# Altium schematic sheet mainSch.SchDoc
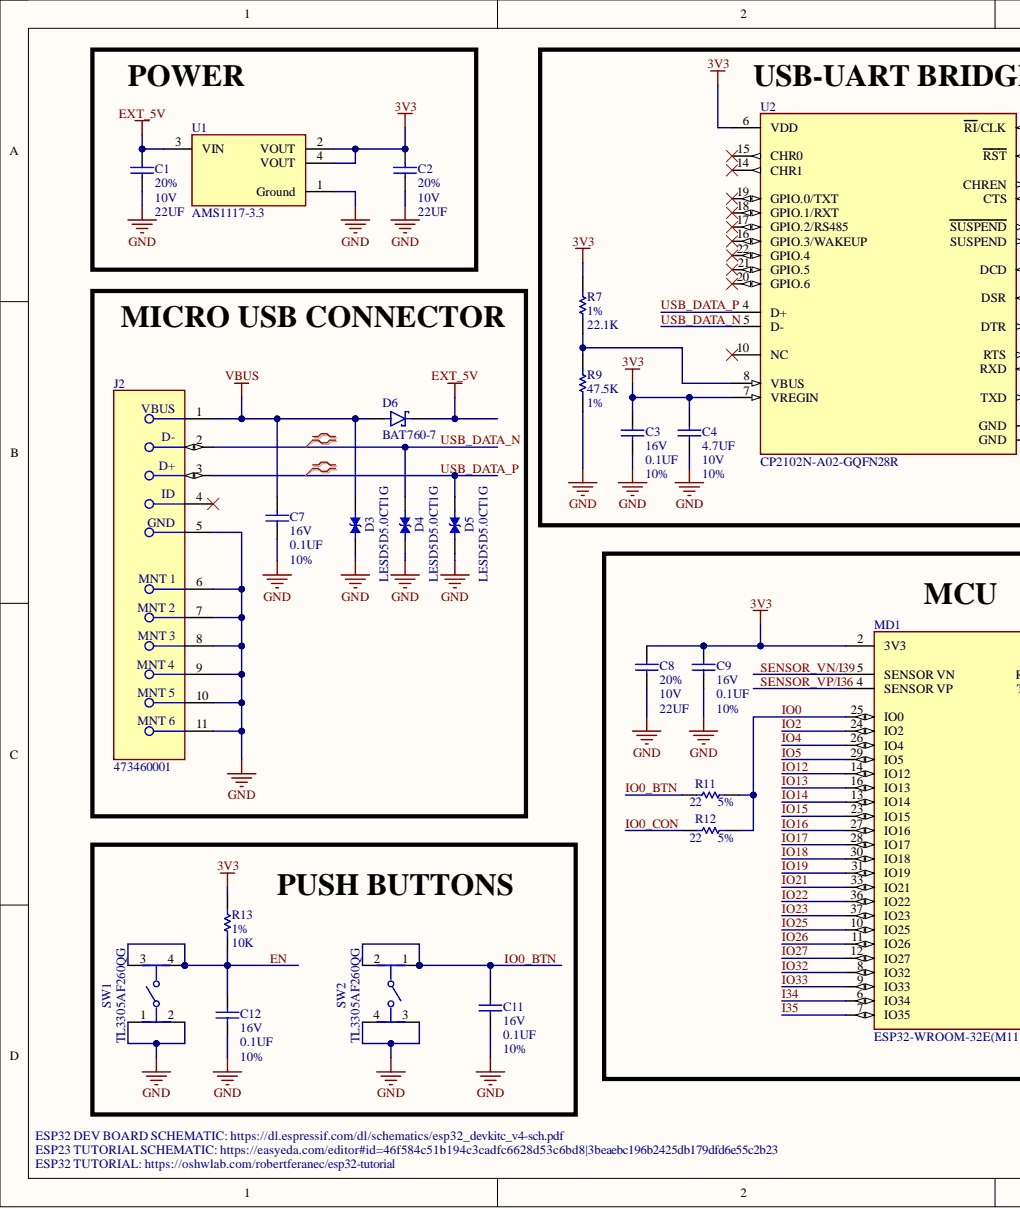
[diagram p0: mainSch.SchDoc, left]
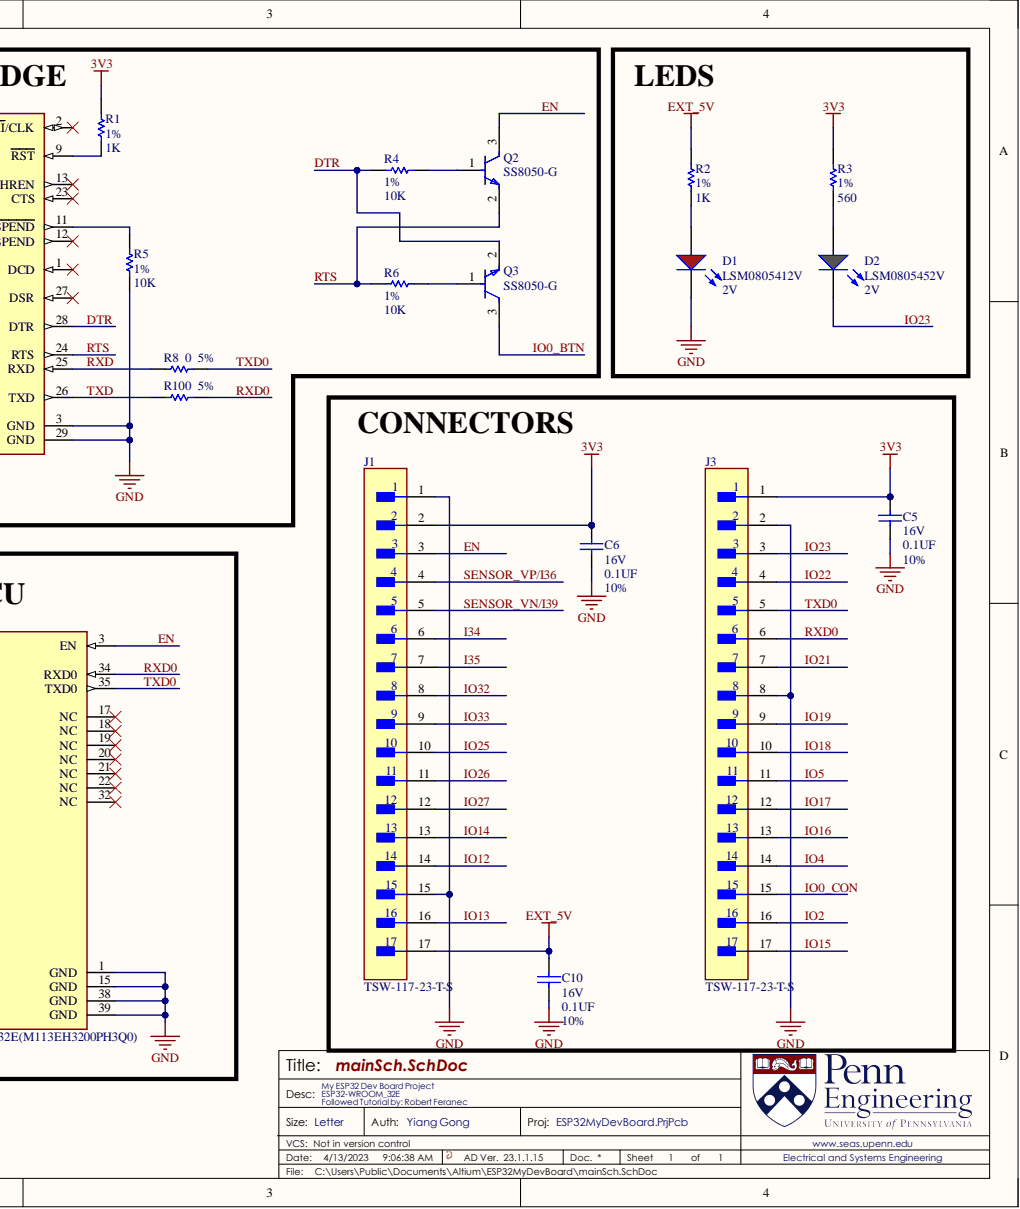
[diagram p1: mainSch.SchDoc, right]

## Parts
C1  value 22UF  Tolerance: 20%  Voltage: 10V  [0805_CAP_60H]  (hidden comment: CAP 0805 22UF 10V 20% X5R)
C2  value 22UF  Tolerance: 20%  Voltage: 10V  [0805_CAP_60H]  (hidden comment: CAP 0805 22UF 10V 20% X5R)
C3  value 0.1UF  Tolerance: 10%  Voltage: 16V  [0402_CAP]  (hidden comment: CAP 0402 0.1UF 16V 10% X7R)
C4  value 4.7UF  Tolerance: 10%  Voltage: 10V  [0603_CAP]  (hidden comment: CAP 0603 4.7UF 10V 10% X5R)
C5  value 0.1UF  Tolerance: 10%  Voltage: 16V  [0402_CAP]  (hidden comment: CAP 0402 0.1UF 16V 10% X7R)
C6  value 0.1UF  Tolerance: 10%  Voltage: 16V  [0402_CAP]  (hidden comment: CAP 0402 0.1UF 16V 10% X7R)
C7  value 0.1UF  Tolerance: 10%  Voltage: 16V  [0402_CAP]  (hidden comment: CAP 0402 0.1UF 16V 10% X7R)
C8  value 22UF  Tolerance: 20%  Voltage: 10V  [0805_CAP_60H]  (hidden comment: CAP 0805 22UF 10V 20% X5R)
C9  value 0.1UF  Tolerance: 10%  Voltage: 16V  [0402_CAP]  (hidden comment: CAP 0402 0.1UF 16V 10% X7R)
C10  value 0.1UF  Tolerance: 10%  Voltage: 16V  [0402_CAP]  (hidden comment: CAP 0402 0.1UF 16V 10% X7R)
C11  value 0.1UF  Tolerance: 10%  Voltage: 16V  [0402_CAP]  (hidden comment: CAP 0402 0.1UF 16V 10% X7R)
C12  value 0.1UF  Tolerance: 10%  Voltage: 16V  [0402_CAP]  (hidden comment: CAP 0402 0.1UF 16V 10% X7R)
D1  LSM0805412V  Forward Voltage: 2V  [LED_0805_RED]
D2  LSM0805452V  Forward Voltage: 2V  [LED_0805]
D3  LESD5D5.0CT1G  [TVS_LESD5D5.0CT1G]
D4  LESD5D5.0CT1G  [TVS_LESD5D5.0CT1G]
D5  LESD5D5.0CT1G  [TVS_LESD5D5.0CT1G]
D6  BAT760-7  [SOD2513X120N]
J1  TSW-117-23-T-S  [FP-TSW-117-23-T-S-MFG]
J2  473460001  [FP-473460001-MFG]
J3  TSW-117-23-T-S  [FP-TSW-117-23-T-S-MFG]
MD1  ESP32-WROOM-32E(M113EH3200PH3Q0)  [FP-ESP32-WROOM-32E_M113EH-MFG]
Q2  SS8050-G  [FP-SS8050-G-MFG]
Q3  SS8050-G  [FP-SS8050-G-MFG]
R1  value 1K  Tolerance: 1%  [0402_RES]  (hidden comment: RES 0402 1K 1%)
R2  value 1K  Tolerance: 1%  [0402_RES]  (hidden comment: RES 0402 1K 1%)
R3  value 560  Tolerance: 1%  [0402_RES]  (hidden comment: RES 0402 560 1%)
R4  value 10K  Tolerance: 1%  [0402_RES]  (hidden comment: RES 0402 10K 1%)
R5  value 10K  Tolerance: 1%  [0402_RES]  (hidden comment: RES 0402 10K 1%)
R6  value 10K  Tolerance: 1%  [0402_RES]  (hidden comment: RES 0402 10K 1%)
R7  value 22.1K  Tolerance: 1%  [0402_RES]  (hidden comment: RES 0402 22.1K 1%)
R8  value 0  Tolerance: 5%  [0402_RES]  (hidden comment: RES 0402 0 5%)
R9  value 47.5K  Tolerance: 1%  [0402_RES]  (hidden comment: RES 0402 47.5K 1%)
R10  value 0  Tolerance: 5%  [0402_RES]  (hidden comment: RES 0402 0 5%)
R11  value 22  Tolerance: 5%  [0402_RES]  (hidden comment: RES 0402 22 5%)
R12  value 22  Tolerance: 5%  [0402_RES]  (hidden comment: RES 0402 22 5%)
R13  value 10K  Tolerance: 1%  [0402_RES]  (hidden comment: RES 0402 10K 1%)
SW1  TL3305AF260QG  [FP-TL3305AF260QG-MFG]
SW2  TL3305AF260QG  [FP-TL3305AF260QG-MFG]
U1  AMS1117-3.3  [FP-AMS1117-IPC_C]
U2  CP2102N-A02-GQFN28R  [FP-CP2102N-A02-GQFN28R-MFG]

## Nets
3V3: C2.2 C3.2 C4.2 C5.2 C6.2 C8.2 C9.2 J1.2 J3.1 MD1.2(3V3) R1.2 R3.2 R7.2 R13.2 U1.2(VOUT) U1.4(VOUT) U2.6(VDD) U2.7(VREGIN)
EXT_5V: C1.2 C10.2 D6.C J1.17 R2.2 U1.3(VIN)
GND: C1.1 C2.1 C3.1 C4.1 C5.1 C6.1 C7.1 C8.1 C9.1 C10.1 C11.1 C12.1 D1.K D3.1 D4.1 D5.1 J1.1 J1.15 J2.5 J2.6 J2.7 J2.8 J2.9 J2.10 J2.11 J3.2 J3.8 MD1.1(GND) MD1.15(GND) MD1.38(GND) MD1.39(GND) R5.1 R9.1 SW1.1 SW1.2 SW2.3 SW2.4 U1.1(Ground) U2.3(GND) U2.29(GND)
VBUS: C7.2 D3.2 D6.A J2.1
DTR: Q3.2 R4.1 U2.28(DTR)
EN: C12.2 J1.3 MD1.3(EN) Q2.3 R13.1 SW1.3 SW1.4
I34: J1.6 MD1.6(IO34)
I35: J1.7 MD1.7(IO35)
IO0: MD1.25(IO0) R11.2 R12.2
IO0_BTN: C11.2 Q3.3 R11.1 SW2.1 SW2.2
IO0_CON: J3.15 R12.1
IO2: J3.16 MD1.24(IO2)
IO4: J3.14 MD1.26(IO4)
IO5: J3.11 MD1.29(IO5)
IO12: J1.14 MD1.14(IO12)
IO13: J1.16 MD1.16(IO13)
IO14: J1.13 MD1.13(IO14)
IO15: J3.17 MD1.23(IO15)
IO16: J3.13 MD1.27(IO16)
IO17: J3.12 MD1.28(IO17)
IO18: J3.10 MD1.30(IO18)
IO19: J3.9 MD1.31(IO19)
IO21: J3.7 MD1.33(IO21)
IO22: J3.4 MD1.36(IO22)
IO23: D2.K J3.3 MD1.37(IO23)
IO25: J1.10 MD1.10(IO25)
IO26: J1.11 MD1.11(IO26)
IO27: J1.12 MD1.12(IO27)
IO32: J1.8 MD1.8(IO32)
IO33: J1.9 MD1.9(IO33)
RTS: Q2.2 R6.1 U2.24(RTS)
RXD: R8.1 U2.25(RXD)
RXD0: J3.6 MD1.34(RXD0) R10.2
SENSOR_VN/I39: J1.5 MD1.5(SENSOR_VN)
SENSOR_VP/I36: J1.4 MD1.4(SENSOR_VP)
TXD: R10.1 U2.26(TXD)
TXD0: J3.5 MD1.35(TXD0) R8.2
USB_DATA_N: D4.2 J2.2 U2.5(D-)
USB_DATA_P: D5.2 J2.3 U2.4(D+)
NetD1_A: D1.A R2.1
NetD2_A: D2.A R3.1
NetQ2_1: Q2.1 R4.2
NetQ3_1: Q3.1 R6.2
NetR1_1: R1.1 U2.9(RST)
NetR5_2: R5.2 U2.11(SUSPEND)
NetR7_1: R7.1 R9.2 U2.8(VBUS)

Not connected: J2 4; MD1 17(NC) 18(NC) 19(NC) 20(NC) 21(NC) 22(NC) 32(NC); U2 1(DCD) 2(RI/CLK) 10(NC) 12(SUSPEND) 13(CHREN) 14(CHR1) 15(CHR0) 16(GPIO.3/WAKEUP) 17(GPIO.2/RS485) 18(GPIO.1/RXT) 19(GPIO.0/TXT) 20(GPIO.6) 21(GPIO.5) 22(GPIO.4) 23(CTS) 27(DSR)

## Library data of the parts
C1 C2 C8 = CMP-011-000507-1: Capacitor Surface Mount 0805 Footprint 22UF 10V 20% Tolerance Ceramic X5R; Manufacturer: Various; Description in Visual: Cap SMT 0805 22UF 10V 20%  Cer X5R; PCB Package: 0805; Component Kind: Standard; Library Name: University of Pennsylvania (ESE Labs); Manufacturer P/N: CAP 0805 22UF 10V 20% X5R; Mount: SMT; P/N: CAP 0805 22UF 10V 20% X5R; Type: Ceramic X5R
  C1: Value: 22UF
C3 C5-C7 C9-C12 = CMP-011-000043-1: Capacitor Surface Mount 0402 Footprint 0.1UF 16V 10% Tolerance Ceramic X7R; Manufacturer: Various; Description in Visual: Cap SMT 0402 0.1UF 16V 10%  Cer X7R; PCB Package: 0402; Component Kind: Standard; Library Name: University of Pennsylvania (ESE Labs); Manufacturer P/N: CAP 0402 0.1UF 16V 10% X7R; Mount: SMT; P/N: CAP 0402 0.1UF 16V 10% X7R; Type: Ceramic X7R
C4 = CMP-011-000329-1: Capacitor Surface Mount 0603 Footprint 4.7UF 10V 10% Tolerance Ceramic X5R; Manufacturer: Various; Description in Visual: Cap SMT 0603 4.7UF 10V 10%  Cer X5R; PCB Package: 0603; Component Kind: Standard; Library Name: University of Pennsylvania (ESE Labs); Manufacturer P/N: CAP 0603 4.7UF 10V 10% X5R; Mount: SMT; P/N: CAP 0603 4.7UF 10V 10% X5R; Type: Ceramic X5R
D1 = CMP-00026-00001-1: Single Color LED, RED, 0805; Test Current: 20mA; Component Kind: Standard; Library Name: University of Pennsylvania (ESE Labs); RoHS Compliant: Yes
D2 = CMP-00038-3: Single Color LED, Green, 0805; Test Current: 20mA; Component Kind: Standard; Library Name: University of Pennsylvania (ESE Labs); RoHS Compliant: Yes
D3-D5 = LESD5D5.0CT1G: Transient Voltage Suppressors for ESD Protection; MF: Leshan Radio Co.; Availability: Not in stock; Check_prices: https://www.snapeda.com/parts/LESD5D5.0CT1G/Leshan+Radio+Co./view-part/?ref=eda; Component Kind: Standard; Library Name: Schlib1.SchLib; MP: LESD5D5.0CT1G; Package: None; Price: None; SnapEDA_Link: https://www.snapeda.com/parts/LESD5D5.0CT1G/Leshan+Radio+Co./view-part/?ref=snap
D6 = BAT760-7: Diode Schottky 30V 1A 2-Pin SOD-323 T/R; MF: Diodes Zetex; Availability: Good; Component Kind: Standard; DigiKey-Purchase-URL: https://snapeda.com/shop?store=DigiKey&id=4817231; Library Name: Schlib1.SchLib; Mouser-Purchase-URL: https://snapeda.com/shop?store=Mouser&id=4817231; MP: BAT760-7; Package: None; Price: None; SnapEDA_Link: https://www.snapeda.com/parts/BAT760-7/Zetex/view-part/4817231/?ref=snap
J1 J3 = CMP-02766-001472-1: CONN HEADER VERT 17POS 2.54MM; Connector Type: Male Pin; Gender: Male; Number of Contacts: 17; Pitch: 0.1inch; Color: Black; Component Kind: Standard; Contact Material: Bronze; Contact Mating Length: 0.23inch; Contact Plating: Tin; Contact Type: Male Pin; Height: 2.54mm; Housing Color: Black; Housing Material: Plastic; Lead Free: Lead Free; Length: 43.2mm; Library Name: Altium Content Vault; Mount: Through Hole; Number of Positions: 17; Number of Rows: 1; Package Quantity: 1; Packaging: Bulk; REACH SVHC: No SVHC; RoHS Compliant: Yes; Series: TSW; Termination: Solder; Width: 2.54mm
J2 = CMP-2000-05246-2: CONN RCPT USB2.0 MICRO B SMD R/A; Manufacturer: Molex; Conn Orientation: Right Angle; Current Rating: 1.8A; Is Female: yes; Number Of Contacts: 5; Pitch: 0.65mm; Shielding: true; Voltage Rating: 30V; Automotive: No; Category: Conn; Component Kind: Standard; ComponentLink2Description: Datasheet; ComponentLink2URL: https://www.molex.com/webdocs/datasheets/pdf/en-us/0473460001_IO_CONNECTORS.pdf; Contact Material: Gold,Nickel; Device Class L1: Connectors; Device Class L2: USB Connectors; Device Class L3: unset; Digikey Description: CONN RCPT USB2.0 MICRO B SMD R/A; Footprint Url: https://www.molex.com/pdm_docs/sd/473460001_sd.pdf; Height: 2.945mm; Lead Free: Yes; Library Name: Altium Content Vault; Manufacturer Part Number: 473460001; Octopart: https://octopart.com/47346-0001-molex-7164928; Package: 0; RoHS: TRUE; Temperature: -30°C to +85°C; Temperature Range High: +85°C; Temperature Range Low: -30°C; Usb Standard: USB 2.0
MD1 = CMP-194065-000012-1: RX TXRX MOD WIFI TRACE ANT SMD; Component Kind: Standard; Library Name: Altium Content Vault
Q2 Q3 = CMP-20235-000001-1: NPN TRANSISTOR 1.5A 40V SOT-23 R; Collector Emitter Breakdown Voltage: 25V; Collector Emitter Voltage (VCEO): 400mV; Max Power Dissipation: 300mW; Transition Frequency: 100MHz; Case/Package: TO-236-3; Component Kind: Standard; Library Name: Altium Content Vault; Max Breakdown Voltage: 25V; Max Collector Current: 1.5A; Mount: Surface Mount; Packaging: Tape and Reel; RoHS Compliant: Yes
R1 R2 = CMP-005-000571-1: Resistor Surface Mount, 1K Ohms, 0402 Footprint, 1% Tolerance 0.063W; Manufacturer: Various; Description in Visual: Res SMT 1K 0402  1%  0.063W; PCB Package: 0402; Component Kind: Standard; Library Name: University of Pennsylvania (ESE Labs); Manufacturer P/N: RES 0402 1K 1%; Mount: SMT; Power Rating: 0.063W
R3 = CMP-005-001506-1: Resistor Surface Mount, 560 Ohms, 0402 Footprint, 1% Tolerance 0.063W; Manufacturer: Various; Manufacturer P/N: RES 0402 560R 1%; Description in Visual: Res SMT 560 0402  1%  0.063W; PCB Package: 0402; Component Kind: Standard; Library Name: University of Pennsylvania (ESE Labs); Mount: SMT; Power Rating: 0.063W
R4-R6 R13 = CMP-005-000226-1: Resistor Surface Mount, 10K Ohms, 0402 Footprint, 1% Tolerance 0.063W; Manufacturer: Various; Description in Visual: Res SMT 10K 0402  1%  0.063W; PCB Package: 0402; Component Kind: Standard; Library Name: University of Pennsylvania (ESE Labs); Manufacturer P/N: RES 0402 10K 1%; Mount: SMT; Power Rating: 0.063W
R7 = CMP-005-000735-1: Resistor Surface Mount, 22.1K Ohms, 0402 Footprint, 1% Tolerance 0.063W; Manufacturer: Various; Description in Visual: Res SMT 22.1K 0402  1%  0.063W; PCB Package: 0402; Component Kind: Standard; Library Name: University of Pennsylvania (ESE Labs); Manufacturer P/N: RES 0402 22.1K 1%; Mount: SMT; Power Rating: 0.063W
R8 R10 = CMP-005-000002-2: Resistor Surface Mount, 0 Ohms, 0402 Footprint, 5% Tolerance 0.063W; Manufacturer: Various; Manufacturer P/N: RES 0402 0R 5%; Description in Visual: Res SMT 0 0402  5%  0.063W; PCB Package: 0402; Component Kind: Standard; Library Name: University of Pennsylvania (ESE Labs); Mount: SMT; Power Rating: 0.063W
R9 = CMP-005-001348-1: Resistor Surface Mount, 47.5K Ohms, 0402 Footprint, 1% Tolerance 0.063W; Manufacturer: Various; Description in Visual: Res SMT 47.5K 0402  1%  0.063W; PCB Package: 0402; Component Kind: Standard; Library Name: University of Pennsylvania (ESE Labs); Manufacturer P/N: RES 0402 47.5K 1%; Mount: SMT; Power Rating: 0.063W
R11 R12 = CMP-005-000731-3: Resistor Surface Mount, 22 Ohms, 0402 Footprint, 5% Tolerance 0.063W; Manufacturer: Various; Manufacturer P/N: RES 0402 22R 5%; Description in Visual: Res SMT 22 0402  5%  0.063W; PCB Package: 0402; Component Kind: Standard; Library Name: University of Pennsylvania (ESE Labs); Mount: SMT; Power Rating: 0.063W
SW1 SW2 = CMP-17879-000010-1: SWITCH TACTILE SPST-NO 50MA 12V; Actuator Type: Standard; Illumination Type: Non-Illuminated; Mechanical Life: 200000Cycles; Operating Force: 260gf; Switch Function: Off-Mom; Switch Travel: 0.2mm; Throw Configuration: SPST; Component Kind: Standard; Lead Free: Lead Free; Library Name: Altium Content Vault; Max Operating Temperature: 70°C; Min Operating Temperature: -20°C; Mount: Surface Mount; Packaging: Tape and Reel; Termination: Gull Wing
U1 = CMP-209535-000001-1: LDO Voltage Regulators 1A, 3.3V; Manufacturer: Advanced Monolithic Systems; Dropout Voltage: 1.1V; Max Junction Temp: +125°C; Max Power Dissipation: 1.2W; Max Supply Voltage: 15V; Nominal Supply Current: 5mA; Number Of Outputs: 1; Output Type: Fixed; Standoff Height: 0.64mm; Automotive: No; Category: IC; Component Kind: Standard; ComponentLink2Description: Datasheet; ComponentLink2URL: http://www.advanced-monolithic.com/pdf/ds1117.pdf; Device Class L1: Integrated Circuits (ICs); Device Class L2: Power Management ICs; Device Class L3: Voltage Regulators - Linear; Height: 1.8mm; Ipc Land Pattern Name: SOT229P700X180-4; Lead Free: Yes; Library Name: Altium Content Vault; Manufacturer Part Number: AMS1117-3.3; Octopart: https://octopart.com/ams1117-3.3-advanced+monolithic+systems-25899300; Output Current: 1A; Output Voltage: 3.3V; Package: AMS1117; RoHS: TRUE; Temperature: -40°C to +125°C; Temperature Range High: +125°C; Temperature Range Low: -40°C
U2 = CMP-08093-000007-1: IC USB TO UART BRIDGE QFN28; Component Kind: Standard; Library Name: Altium Content Vault
